# Altium schematic sheet ADC.SchDoc
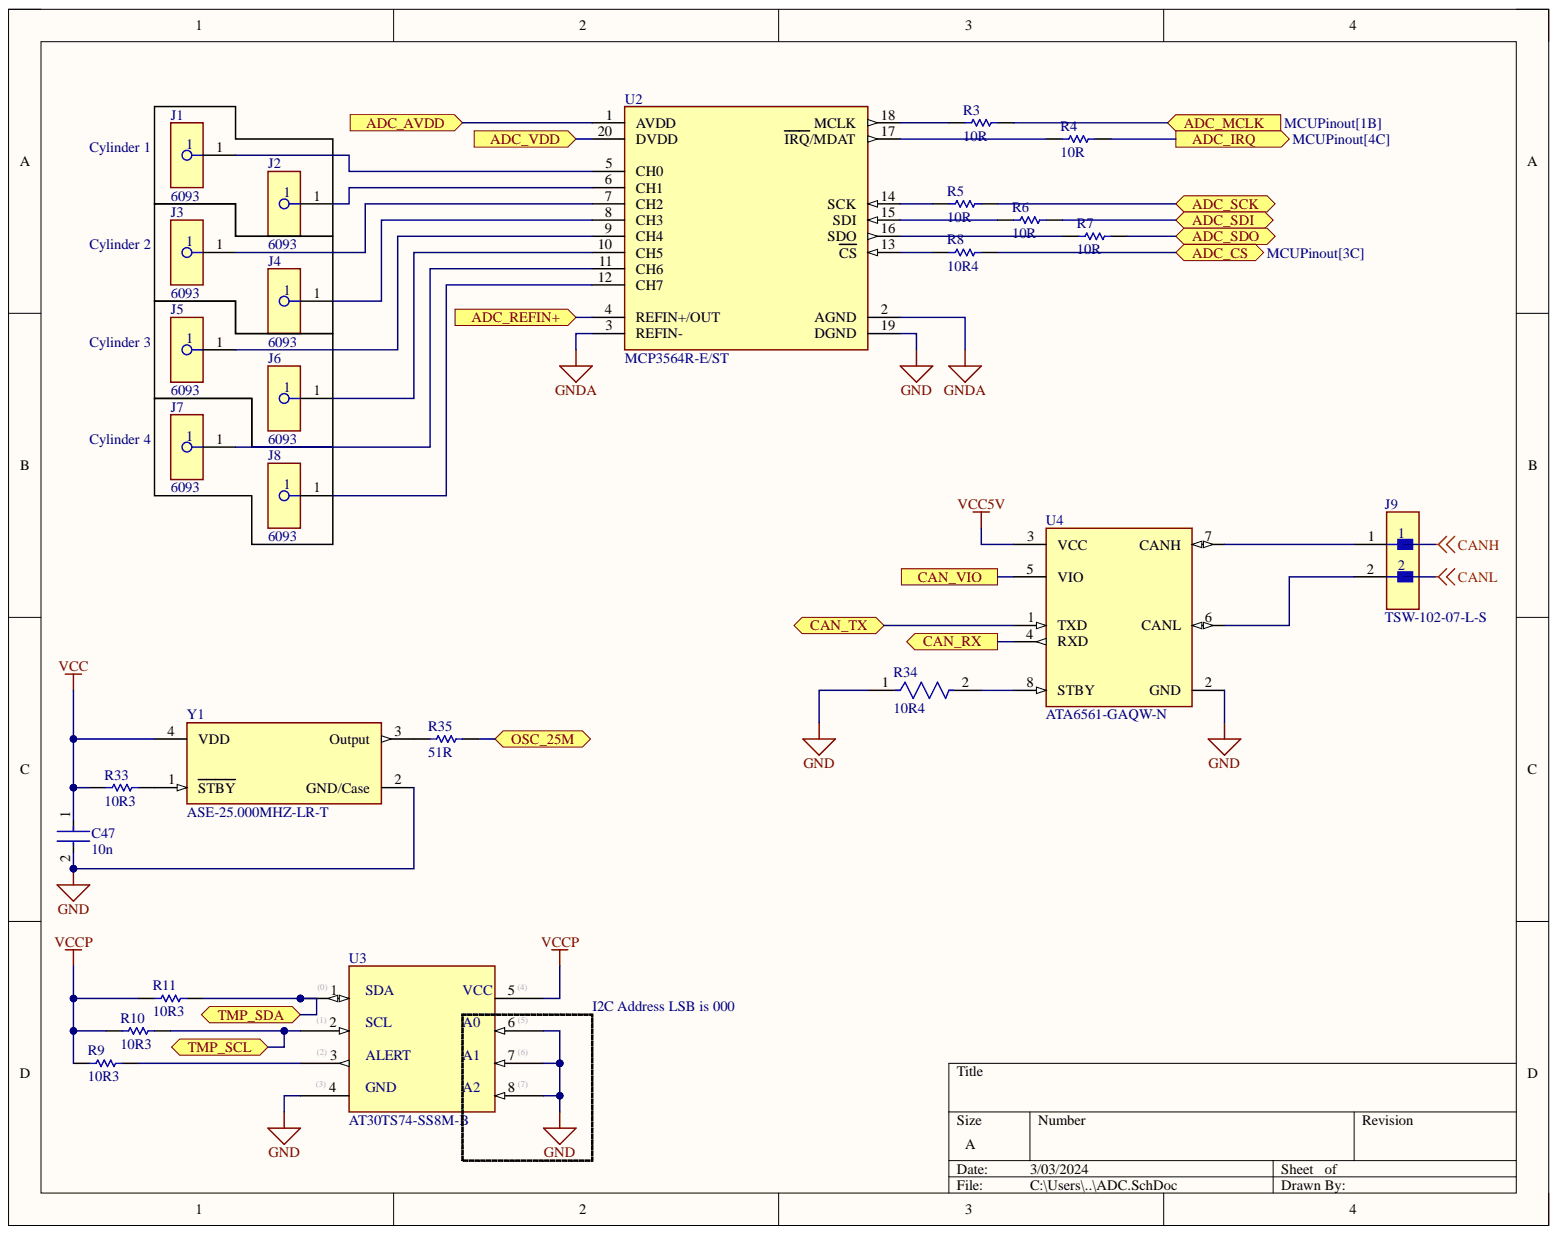
[diagram p0: ADC.SchDoc]

## Parts
C47  10n  [FP-GRM033-0_03-MFG]
J1  6093  [FP-6093-MFG]
J2  6093  [FP-6093-MFG]
J3  6093  [FP-6093-MFG]
J4  6093  [FP-6093-MFG]
J5  6093  [FP-6093-MFG]
J6  6093  [FP-6093-MFG]
J7  6093  [FP-6093-MFG]
J8  6093  [FP-6093-MFG]
J9  TSW-102-07-L-S  [FP-TSW-102-07-L-S-MFG]
R3  10R  [RESC2013X60X35ML10T20]
R4  10R  [RESC2013X60X35ML10T20]
R5  10R  [RESC2013X60X35ML10T20]
R6  10R  [RESC2013X60X35ML10T20]
R7  10R  [RESC2013X60X35ML10T20]
R8  10R4  [RESC2013X60X35ML10T20]
R9  10R3  [RESC3116X65X40ML10T20]
R10  10R3  [RESC3116X65X40ML10T20]
R11  10R3  [RESC3116X65X40ML10T20]
R33  10R3  [RESC3116X65X40ML10T20]
R34  10R4  [FP-RC0603-0_55-IPC_C]
R35  51R  [RESC3116X65X40ML10T20]
U2  MCP3564R-E/ST  [FP-C04-088-MFG]
U3  AT30TS74-SS8M-B  [MCHP-SN8_V]
U4  ATA6561-GAQW-N  [FP-C04-057-OA-MFG]
Y1  ASE-25.000MHZ-LR-T  [FP-ASE-MFG]

## Ports
ADC_AVDD, ADC_CS, ADC_IRQ, ADC_MCLK, ADC_REFIN+, ADC_SCK, ADC_SDI, ADC_SDO, ADC_VDD, CAN_RX, CAN_TX, CAN_VIO, OSC_25M, TMP_SCL, TMP_SDA

## Nets
GND: C47.2 R34.1 U2.19(DGND) U3.3 U3.5 U3.6 U3.7 U4.2(GND) Y1.2(GND/Case)
GNDA: U2.2(AGND) U2.3(REFIN-)
VCC: C47.1 R33.1 Y1.4(VDD)
VCC5V: U4.3(VCC)
VCCP: R9.1 R10.1 R11.1 U3.4
ADC_AVDD: U2.1(AVDD) ; port ADC_AVDD
ADC_CS: R8.2 ; port ADC_CS
ADC_IRQ: R4.2 ; port ADC_IRQ
ADC_MCLK: R3.2 ; port ADC_MCLK
ADC_REFIN+: U2.4(REFIN+/OUT) ; port ADC_REFIN+
ADC_SCK: R5.2 ; port ADC_SCK
ADC_SDI: R6.2 ; port ADC_SDI
ADC_SDO: R7.2 ; port ADC_SDO
ADC_VDD: U2.20(DVDD) ; port ADC_VDD
CAN_RX: U4.4(RXD) ; port CAN_RX
CAN_TX: U4.1(TXD) ; port CAN_TX
CAN_VIO: U4.5(VIO) ; port CAN_VIO
OSC_25M: R35.2 ; port OSC_25M
TMP_SCL: R10.2 U3.1 ; port TMP_SCL
TMP_SDA: R11.2 U3.0 ; port TMP_SDA
NetJ1_1: J1.1 U2.5(CH0)
NetJ2_1: J2.1 U2.6(CH1)
NetJ3_1: J3.1 U2.7(CH2)
NetJ4_1: J4.1 U2.8(CH3)
NetJ5_1: J5.1 U2.9(CH4)
NetJ6_1: J6.1 U2.10(CH5)
NetJ7_1: J7.1 U2.11(CH6)
NetJ8_1: J8.1 U2.12(CH7)
NetJ9_1: J9.1 U4.7(CANH)
NetJ9_2: J9.2 U4.6(CANL)
NetR3_1: R3.1 U2.18(MCLK)
NetR4_1: R4.1 U2.17(IRQ/MDAT)
NetR5_1: R5.1 U2.14(SCK)
NetR6_1: R6.1 U2.15(SDI)
NetR7_1: R7.1 U2.16(SDO)
NetR8_1: R8.1 U2.13(CS)
NetR9_2: R9.2 U3.2
NetR33_2: R33.2 Y1.1(STBY)
NetR34_2: R34.2 U4.8(STBY)
NetR35_1: R35.1 Y1.3(Output)
CANH:
CANL:

## Library data of the parts
C47 = CMP-06035-000062-2: Chip Multilayer Ceramic Capacitors for General Purpose, 0201, 0.10uF, X5R, 15%, 10%, 6.3V; Capacitance: 100nF; Case Code (Imperial): 0201; Case Code (Metric): 0603; Case/Package: 0201; Component Kind: Standard; Depth: 0.3mm; Dielectric: X5R; Height: 0.3mm; Lead Free: Lead Free; Length: 0.6mm; Library Name: Altium Content Vault; Material: Ceramic; Max Operating Temperature: 85°C; Min Operating Temperature: -55°C; Mount: Surface Mount; Package Quantity: 1; Packaging: Tape and Reel; Radiation Hardening: No; REACH SVHC: No SVHC; Termination: SMD/SMT; Thickness: 0.013inch; Tolerance: 10%; Voltage: 6.3V; Voltage Rating: 6.3V; Voltage Rating (DC): 6.3V; Weight: 6E-06oz; Width: 0.012inch
J1-J8 = CMP-19636-000026-1: CONN BANANA JACK SOLDER LUG RED; Body Material: Brass; Gender: Female; Hole Diameter: 0.12inch; Insulation: Mating End Insulated; Number of Contacts: 3; Pitch: 0.375inch; Color: Red; Component Kind: Standard; Contact Material: Brass; Contact Plating: Tin; Insulation Material: Nylon; Lead Free: Lead Free; Library Name: Altium Content Vault; Material: Paper; Mount: Panel; Number of Positions: 3; Number of Rows: 1; Orientation: Straight; Package Quantity: 1; Packaging: Bulk; REACH SVHC: No SVHC; RoHS Compliant: No; Series: -; Termination: Solder
J9 = CMP-02766-001428-1: CONN HEADER VERT 2POS 2.54MM; Connector Type: Male Pin; Current Rating: 7.6A; Gender: Male; Max Voltage Rating (AC): 550V; Number of Contacts: 2; Color: Black; Component Kind: Standard; Contact Material: Bronze; Contact Mating Length: 0.23inch; Contact Plating: Gold; Contact Type: Male Pin; Depth: 2.54mm; Housing Color: Black; Housing Material: Polyester; Lead Free: Lead Free; Length: 5.08mm; Library Name: Altium Content Vault; Max Current Rating: 7.6A; Max Operating Temperature: 125°C; Min Operating Temperature: -55°C; Mount: Through Hole; Number of Positions: 2; Number of Rows: 1; Orientation: Straight; Package Quantity: 1; Packaging: Bulk; Pitch: 2.54mm; Radiation Hardening: No; REACH SVHC: No SVHC; RoHS Compliant: Yes; Series: TSW; Termination: Solder; Voltage Rating: 550V; Width: 2.54mm
R3-R8 = CMP-2100-03670-1: Chip Resistor, 10 Ohm, +/- 1%, 0.125 W, -55 to 155 degC, 0805 (2012 Metric), RoHS, Tape and Reel; Manufacturer: Yageo; Max Power Dissipation: 125mW; Resistance: 10Ohm; Temperature Coefficient: 200ppm/degreesC; Voltage Rating: 150V; Case Code (Imperial): 0805; Case Code (Metric): 2012; Case/Package: 0805; Component Kind: Standard; Composition: ThickFilm; Datasheet URL: http://www.yageo.com/NewPortal/yageodocoutput?fileName=/pdf/R-Chip/PYu-RC_Group_51_RoHS_L_5.pdf; Datasheet Version: Rev. 5, 10/2016; Depth: 1.25mm; Height: 0.5mm; Lead Free: LeadFree; Length: 2mm; Library Name: Altium Content Vault; Manufacturer URL: http://www.yageo.com/; Max Operating Temperature: 155degC; Min Operating Temperature: -55degC; Number of Pins: 2; Number of Terminations: 2; Package Description: 2-Pin Surface Mounted Device, Body 2 x 1.25 mm; Package Quantity: 1; Package Reference: 0805; Packaging: TapeandReel; Power Rating: 125mW; REACH SVHC: NoSVHC; RoHSCompliant: true; Termination: SMD/SMT; Tolerance: 1%; Voltage Rating (DC): 150V; Width: 1.25mm
R9-R11 R33 R35 = CMP-2100-03678-1: Chip Resistor, 1 KOhm, +/- 1%, 0.25 W, -55 to 155 degC, 1206 (3216 Metric), RoHS, Tape and Reel; Manufacturer: Yageo; Power Rating: 250mW; Resistance: 1KOhm; Temperature Coefficient: 100ppm/degreesC; Voltage Rating: 200V; Case Code (Imperial): 1206; Case Code (Metric): 3216; Case/Package: 1206; Component Kind: Standard; Composition: ThickFilm; Datasheet URL: http://www.yageo.com/NewPortal/yageodocoutput?fileName=/pdf/R-Chip/PYu-RC_Group_51_RoHS_L_5.pdf; Datasheet Version: Rev. 5, 10/2016; Depth: 1.6mm; Height: 0.55mm; Lead Free: LeadFree; Length: 3.1mm; Library Name: Altium Content Vault; Manufacturer URL: http://www.yageo.com/; Max Operating Temperature: 155degC; Military Standard: Not; Min Operating Temperature: -55degC; Package Description: 2-Pin Surface Mount Device, Body 3.1 x 1.6 mm; Package Reference: 1206.0; Packaging: TapeandReel; REACH SVHC: Unknown; RoHSCompliant: true; Termination: SMD/SMT; Tolerance: 1%
R34 = CMP-2100-03664-2: RES 10K OHM 1% 1/10W 0603; Max Power Dissipation: 100mW; Resistance: 10kR; Temperature Coefficient: 100ppm/°C; Voltage Rating: 75V; Voltage Rating (DC): 50V; Case Code (Imperial): 0603; Case Code (Metric): 1608; Case/Package: 0603; Component Kind: Standard; Construction: Rectangular; Depth: 0.8mm; Features: Moisture Resistant; Height: 0.45mm; Lead Free: Lead Free; Lead Pitch: 0.3mm; Length: 1.6mm; Library Name: Altium Content Vault; Max Operating Temperature: 155°C; Military Standard: Not; Min Operating Temperature: -55°C; Number of Pins: 2; Number of Terminations: 2; Packaging: Tape and Reel; Power Rating: 100mW; Resistance Tolerance: 1%; Resistor Type: Thick Film; RoHS Compliant: Yes; Series: RC; Size Code: 0603; Termination: SMD/SMT; Tolerance: 1%; Weight: 7.1E-05oz; Width: 0.8mm
U2 = CMP-00252-111742343-1: MCP3564R-E/ST 8-Channel Single ADC Delta-Sigma 153.6ksps 24-bit Serial 20-Pin TSSOP Tube; Interface: SPI; Max Junction Temperature (Tj): 150°C; Max Supply Voltage: 3.6V; Min Supply Voltage: 1.8V; Number of Channels: 8; Number of Converters: 1; Ambient Temperature Range High: 125°C; Component Kind: Standard; Height: 1.2mm; Library Name: Altium Content Vault; Lifecycle Status: Production; Max Operating Temperature: 125°C; Min Operating Temperature: -40°C; NexarPartId: 111742343; Sampling Rate: 153.6ksps
U3 = AT30TS74_0217: Temp Sensor Digital Serial (2-Wire, I2C, SMBus) 8-Pin SOIC Tube; Manufacturer: Microchip; Accuracy-Max (Cel): 3°C; Body Breadth: 3.9inch; Body Height: 1.75mm; Body Length or Diameter: 4.9mm; Max Supply Voltage: 5.5V; Min Supply Voltage: 1.7V; Number of Bits: 12; Operating Current-Max: 800uA; Package Shape/Style: Rectangular; Sensor Type: TEMPERATURE SENSOR,SWITCH/DIGITAL OUTPUT,SERIAL; Category: Uncategorized; Component Kind: Standard; ComponentLink1Description: https://datasheet.ciiva.com:443/pdfs/VipMasterIC/IC/ATML/ATML-S-A0001248569/ATML-S-A0001248569-1.pdf?src-supplier=IHS+Markit; ComponentLink1URL: https://datasheet.ciiva.com:443/pdfs/VipMasterIC/IC/ATML/ATML-S-A0001248569/ATML-S-A0001248569-1.pdf?src-supplier=IHS+Markit; ComponentLink2Description: http://www.microchip.com/mymicrochip/filehandler.aspx?ddocname=en590216&src-supplier=Digikey; ComponentLink2URL: http://www.microchip.com/mymicrochip/filehandler.aspx?ddocname=en590216&src-supplier=Digikey; ComponentLink3Description: http://www.atmel.com/Images/Atmel-8897-DTS-AT30TS74-Datasheet.pdf?src-supplier=Avnet; ComponentLink3URL: http://www.atmel.com/Images/Atmel-8897-DTS-AT30TS74-Datasheet.pdf?src-supplier=Avnet; ComponentLink4Description: https://datasheet.octopart.com/AT30TS74-SS8M-B-Microchip-datasheet-140384934.pdf?src-supplier=microchipDIRECT; ComponentLink4URL: https://datasheet.octopart.com/AT30TS74-SS8M-B-Microchip-datasheet-140384934.pdf?src-supplier=microchipDIRECT; Housing Material: Plastic; Manufacturer Part Number: AT30TS74-SS8M-B; Max Operating Temperature: 125°C; Min Operating Temperature: -40°C; Mounting Technology: Surface Mount; Output Interface Type: 2-Wire; Pricing: 1=0.82, 10=0.82, 50=0.68, 100=0.662, 1000=0.662, 10000=0.654 (USD); Reach SVHC Compliant: Yes; Stock: 7962; Supplier: Mouser; Supplier Part Number: 556-AT30TS74-SS8M-B; Termination: Solder; Library Name: DelSlowEGT.SCHLIB
U4 = CMP-06279-000002-1: IC TRANSCEIVER 1/1 8SO; Manufacturer: Microchip Technology; Interface: CAN; Max Junction Temp: +150°C; Max Supply Voltage: 5.5V; Min Supply Voltage: 2.8V; Nominal Supply Current: 50mA; Standoff Height: 0.1mm; Automotive: No; Category: IC; Component Kind: Standard; ComponentLink2Description: Datasheet; ComponentLink2URL: http://ww1.microchip.com/downloads/en/DeviceDoc/ATA6560-ATA6561-High-Speed-CAN-Transceiver-DS20005991A.pdf; Device Class L1: Integrated Circuits (ICs); Device Class L2: Interface ICs; Device Class L3: unset; Digikey Description: IC TRANSCEIVER 1/1 8SO; Footprint Url: http://ww1.microchip.com/downloads/en/DeviceDoc/08L_SOIC_150_3dot9mm_OA_C04-00057e.pdf; Height: 1.75mm; Ipc Land Pattern Name: SOIC127P600X155-8; Lead Free: Yes; Library Name: Altium Content Vault; Manufacturer Part Number: ATA6561-GAQW-N; Mouser Description: CAN - Controller Area Network 5Mbps Unpowered/Standby/Normal 4.5V to 5.5V 8-Pin SOIC T/R; Octopart: https://octopart.com/ata6561-gaqw-n-microchip-93294113; Package: C04-057-OA; RoHS: TRUE; Temperature: -40°C to +150°C; Temperature Range High: +150°C; Temperature Range Low: -40°C
Y1 = CMP-27762-000357-1: XTAL Oscillator 25MHz ±25ppm CMOS 3.3V 4-SMD 3.2mm x 2.5mm; Load Capacitance: 15pF; Max Supply Current: 13mA; Max Supply Voltage: 3.465V; Min Supply Voltage: 3.135V; Case/Package: SMD/SMT; Component Kind: Standard; Frequency: 25MHz; Frequency Stability: 25ppm; Height: 0.047inch; Lead Free: Lead Free; Length: 0.126inch; Library Name: Altium Content Vault; Max Operating Temperature: 85°C; Min Operating Temperature: -40°C; Mount: Surface Mount; Number of Pins: 4; Operating Frequency: 25 MHz; Operating Supply Voltage: 3.3V; Package Quantity: 1000; Packaging: Tape and Reel; REACH SVHC: No SVHC; Series: ASE; Termination: SMD/SMT; Width: 0.098inch
